# Altium schematic sheet RELAY.SchDoc(RELAY)
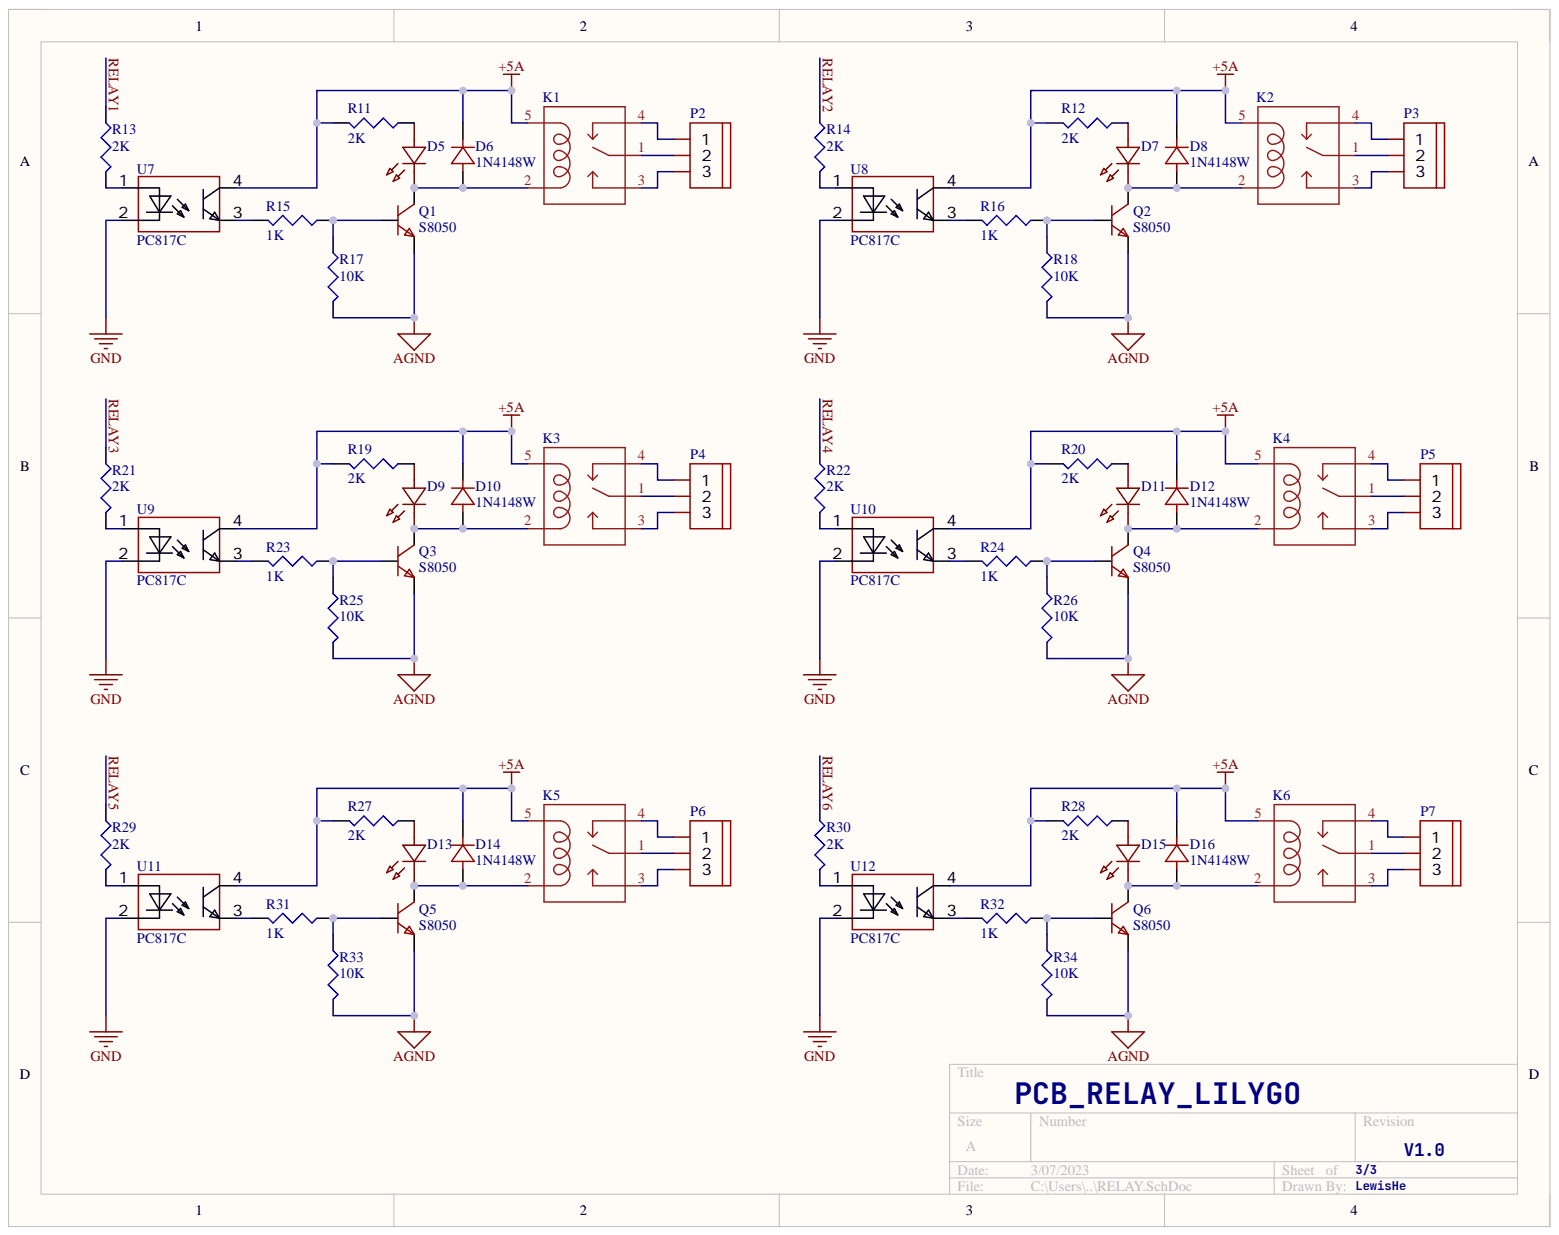
[diagram p0: RELAY.SchDoc]

## Parts
D5  BLUE_LED  [LED0603_RED]  (value not drawn)
D6  1N4148W  [SOD-123_L2.7-W1.6-LS3.8-RD]
D7  BLUE_LED  [LED0603_RED]  (value not drawn)
D8  1N4148W  [SOD-123_L2.7-W1.6-LS3.8-RD]
D9  BLUE_LED  [LED0603_RED]  (value not drawn)
D10  1N4148W  [SOD-123_L2.7-W1.6-LS3.8-RD]
D11  BLUE_LED  [LED0603_RED]  (value not drawn)
D12  1N4148W  [SOD-123_L2.7-W1.6-LS3.8-RD]
D13  BLUE_LED  [LED0603_RED]  (value not drawn)
D14  1N4148W  [SOD-123_L2.7-W1.6-LS3.8-RD]
D15  BLUE_LED  [LED0603_RED]  (value not drawn)
D16  1N4148W  [SOD-123_L2.7-W1.6-LS3.8-RD]
K1  JS1-5V-F  [RELAY-TH_JS1-24V-F]  (value not drawn)
K2  JS1-5V-F  [RELAY-TH_JS1-24V-F]  (value not drawn)
K3  JS1-5V-F  [RELAY-TH_JS1-24V-F]  (value not drawn)
K4  JS1-5V-F  [RELAY-TH_JS1-24V-F]  (value not drawn)
K5  JS1-5V-F  [RELAY-TH_JS1-24V-F]  (value not drawn)
K6  JS1-5V-F  [RELAY-TH_JS1-24V-F]  (value not drawn)
P2  WJ500V-5.08-3P  [CONN-TH_3P-P5.00_WJ500V-5.08-3P]  (value not drawn)
P3  WJ500V-5.08-3P  [CONN-TH_3P-P5.00_WJ500V-5.08-3P]  (value not drawn)
P4  WJ500V-5.08-3P  [CONN-TH_3P-P5.00_WJ500V-5.08-3P]  (value not drawn)
P5  WJ500V-5.08-3P  [CONN-TH_3P-P5.00_WJ500V-5.08-3P]  (value not drawn)
P6  WJ500V-5.08-3P  [CONN-TH_3P-P5.00_WJ500V-5.08-3P]  (value not drawn)
P7  WJ500V-5.08-3P  [CONN-TH_3P-P5.00_WJ500V-5.08-3P]  (value not drawn)
Q1  S8050  [SOT-23-3_L2.9-W1.6-P1.90-LS2.8-BR]
Q2  S8050  [SOT-23-3_L2.9-W1.6-P1.90-LS2.8-BR]
Q3  S8050  [SOT-23-3_L2.9-W1.6-P1.90-LS2.8-BR]
Q4  S8050  [SOT-23-3_L2.9-W1.6-P1.90-LS2.8-BR]
Q5  S8050  [SOT-23-3_L2.9-W1.6-P1.90-LS2.8-BR]
Q6  S8050  [SOT-23-3_L2.9-W1.6-P1.90-LS2.8-BR]
R11  2K  [R0402]
R12  2K  [R0402]
R13  2K  [R0402]
R14  2K  [R0402]
R15  1K  [R0402]
R16  1K  [R0402]
R17  10K  [R0402]
R18  10K  [R0402]
R19  2K  [R0402]
R20  2K  [R0402]
R21  2K  [R0402]
R22  2K  [R0402]
R23  1K  [R0402]
R24  1K  [R0402]
R25  10K  [R0402]
R26  10K  [R0402]
R27  2K  [R0402]
R28  2K  [R0402]
R29  2K  [R0402]
R30  2K  [R0402]
R31  1K  [R0402]
R32  1K  [R0402]
R33  10K  [R0402]
R34  10K  [R0402]
U7  PC817C  [SOP-4_L6.5-W4.6-P2.54-LS10.2-TL]
U8  PC817C  [SOP-4_L6.5-W4.6-P2.54-LS10.2-TL]
U9  PC817C  [SOP-4_L6.5-W4.6-P2.54-LS10.2-TL]
U10  PC817C  [SOP-4_L6.5-W4.6-P2.54-LS10.2-TL]
U11  PC817C  [SOP-4_L6.5-W4.6-P2.54-LS10.2-TL]
U12  PC817C  [SOP-4_L6.5-W4.6-P2.54-LS10.2-TL]

## Nets
+5A: D6.1 D8.1 D10.1 D12.1 D14.1 D16.1 K1.5 K2.5 K3.5 K4.5 K5.5 K6.5 R11.2 R12.2 R19.2 R20.2 R27.2 R28.2 U7.4 U8.4 U9.4 U10.4 U11.4 U12.4
AGND: Q1.2 Q2.2 Q3.2 Q4.2 Q5.2 Q6.2 R17.2 R18.2 R25.2 R26.2 R33.2 R34.2
GND: U7.2 U8.2 U9.2 U10.2 U11.2 U12.2
RELAY1: R13.1
RELAY2: R14.1
RELAY3: R21.1
RELAY4: R22.1
RELAY5: R29.1
RELAY6: R30.1
NetD5_1: D5.1 R11.1
NetD5_2: D5.2 D6.2 K1.2 Q1.3
NetD7_1: D7.1 R12.1
NetD7_2: D7.2 D8.2 K2.2 Q2.3
NetD9_1: D9.1 R19.1
NetD9_2: D9.2 D10.2 K3.2 Q3.3
NetD11_1: D11.1 R20.1
NetD11_2: D11.2 D12.2 K4.2 Q4.3
NetD13_1: D13.1 R27.1
NetD13_2: D13.2 D14.2 K5.2 Q5.3
NetD15_1: D15.1 R28.1
NetD15_2: D15.2 D16.2 K6.2 Q6.3
NetK1_1: K1.1 P2.2
NetK1_3: K1.3 P2.3
NetK1_4: K1.4 P2.1
NetK2_1: K2.1 P3.2
NetK2_3: K2.3 P3.3
NetK2_4: K2.4 P3.1
NetK3_1: K3.1 P4.2
NetK3_3: K3.3 P4.3
NetK3_4: K3.4 P4.1
NetK4_1: K4.1 P5.2
NetK4_3: K4.3 P5.3
NetK4_4: K4.4 P5.1
NetK5_1: K5.1 P6.2
NetK5_3: K5.3 P6.3
NetK5_4: K5.4 P6.1
NetK6_1: K6.1 P7.2
NetK6_3: K6.3 P7.3
NetK6_4: K6.4 P7.1
NetQ1_1: Q1.1 R15.1 R17.1
NetQ2_1: Q2.1 R16.1 R18.1
NetQ3_1: Q3.1 R23.1 R25.1
NetQ4_1: Q4.1 R24.1 R26.1
NetQ5_1: Q5.1 R31.1 R33.1
NetQ6_1: Q6.1 R32.1 R34.1
NetR13_2: R13.2 U7.1
NetR14_2: R14.2 U8.1
NetR15_2: R15.2 U7.3
NetR16_2: R16.2 U8.3
NetR21_2: R21.2 U9.1
NetR22_2: R22.2 U10.1
NetR23_2: R23.2 U9.3
NetR24_2: R24.2 U10.3
NetR29_2: R29.2 U11.1
NetR30_2: R30.2 U12.1
NetR31_2: R31.2 U11.3
NetR32_2: R32.2 U12.3

## Library data of the parts
D5 D7 D9 D11 D13 D15 = LED0603: 蓝灯; Component Kind: Standard; Library Name: 常用.SCHLIB
D6 D8 D10 D12 D14 D16 = 1N4148W: SOD-123 贴片二极管; Component Kind: Standard; Library Name: 常用.SCHLIB
K1 K2 K4-K6 = JS1-24V-F: JS1-5V-F 继电器; Component Kind: Standard; Library Name: 常用.SCHLIB
K3 = JS1-24V-F: HRS4H-S-DC5V 继电器; Component Kind: Standard; Library Name: 常用.SCHLIB
Q1-Q6 = S8050: S8050 J3Y SOT-23; Component Kind: Standard; Library Name: 常用.SCHLIB
R11-R34 = Res3: Resistor; Component Kind: Standard; LatestRevisionDate: 29-May-2009; LatestRevisionNote: IPC-7351 Footprint Added.; Library Name: Miscellaneous Devices.IntLib; PackageDescription: Chip Resistor; PackageReference: J1-0603; Published: 8-Jun-2000; Signal Integrity: Res; Simulation: RESISTOR; Publisher: Altium Limited
  R11-R14 R19-R22 R27-R30: Value: 2K
  R15 R16 R23 R24 R31 R32: Value: 1K
  R17 R18 R25 R26 R33 R34: Value: 10K
U7-U12 = PC817C: 输入电压类型:DC;输出类型:光电三极管;输出通道数:1;正向压降:1.2V;反向电压:6V;输出电流:50mA;接收端电压:70V;集射极饱和电压(Vce(sat)@Ic,IF):100mV@1mA,20mA;上升时间:4us@2mA,100Ω;下降时间:3us@2mA,100Ω;隔离电压(rms):5kV;工作温度:-40℃~+100℃;; Component Kind: Standard; Library Name: 常用.SCHLIB
P2-P7 = WJ500V-5.08-3P: Component Kind: Standard; Description: WJ500V-5.08-3P; Library Name: HW_RELAY_MAIN.SCHLIB
